# Altium schematic sheet FPGA_B34.SchDoc(FPGA_B34)
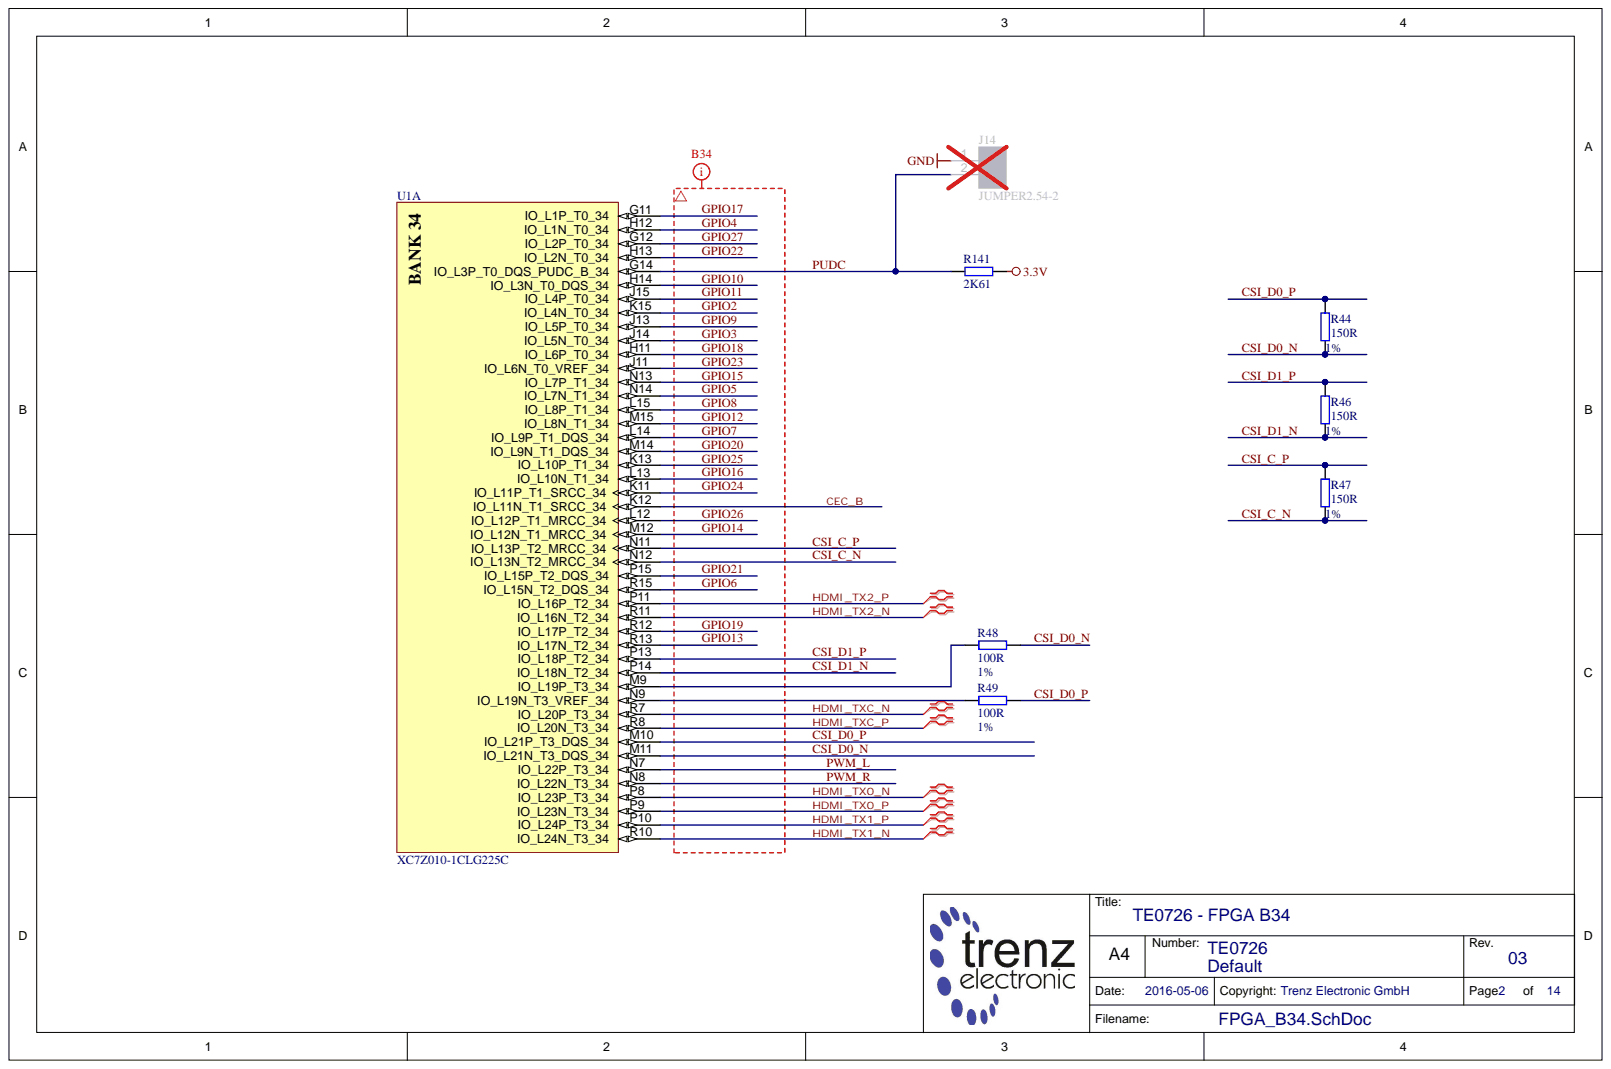
[diagram p0: FPGA_B34.SchDoc]

## Parts
J14  JUMPER2.54-2  [JUMPER2_54-2]
R44  150R  Tolerance: 1%  [RESC1005X40N]
R46  150R  Tolerance: 1%  [RESC1005X40N]
R47  150R  Tolerance: 1%  [RESC1005X40N]
R48  100R  [RESC1005X40N]
R49  100R  Tolerance: 1%  [RESC1005X40N]
R141  2K61  [RESC1005X40N]
U1  XC7Z010-1CLG225C  [BGA225C80P15X15_1300X1300X150B40N]  (part A)

## Nets
3.3V: R141.2
GND: J14.1
CEC_B: U1.K12(IO_L11N_T1_SRCC_34)
CSI_C_N: R47.2 U1.N12(IO_L13N_T2_MRCC_34)
CSI_C_P: R47.1 U1.N11(IO_L13P_T2_MRCC_34)
CSI_D0_N: R44.1 R48.2 U1.M11(IO_L21N_T3_DQS_34)
CSI_D0_P: R44.2 R49.2 U1.M10(IO_L21P_T3_DQS_34)
CSI_D1_N: R46.2 U1.P14(IO_L18N_T2_34)
CSI_D1_P: R46.1 U1.P13(IO_L18P_T2_34)
GPIO2: U1.K15(IO_L4N_T0_34)
GPIO3: U1.J14(IO_L5N_T0_34)
GPIO4: U1.H12(IO_L1N_T0_34)
GPIO5: U1.N14(IO_L7N_T1_34)
GPIO6: U1.R15(IO_L15N_T2_DQS_34)
GPIO7: U1.L14(IO_L9P_T1_DQS_34)
GPIO8: U1.L15(IO_L8P_T1_34)
GPIO9: U1.J13(IO_L5P_T0_34)
GPIO10: U1.H14(IO_L3N_T0_DQS_34)
GPIO11: U1.J15(IO_L4P_T0_34)
GPIO12: U1.M15(IO_L8N_T1_34)
GPIO13: U1.R13(IO_L17N_T2_34)
GPIO14: U1.M12(IO_L12N_T1_MRCC_34)
GPIO15: U1.N13(IO_L7P_T1_34)
GPIO16: U1.L13(IO_L10N_T1_34)
GPIO17: U1.G11(IO_L1P_T0_34)
GPIO18: U1.H11(IO_L6P_T0_34)
GPIO19: U1.R12(IO_L17P_T2_34)
GPIO20: U1.M14(IO_L9N_T1_DQS_34)
GPIO21: U1.P15(IO_L15P_T2_DQS_34)
GPIO22: U1.H13(IO_L2N_T0_34)
GPIO23: U1.J11(IO_L6N_T0_VREF_34)
GPIO24: U1.K11(IO_L11P_T1_SRCC_34)
GPIO25: U1.K13(IO_L10P_T1_34)
GPIO26: U1.L12(IO_L12P_T1_MRCC_34)
GPIO27: U1.G12(IO_L2P_T0_34)
HDMI_TX0_N: U1.P8(IO_L23P_T3_34)
HDMI_TX0_P: U1.P9(IO_L23N_T3_34)
HDMI_TX1_N: U1.R10(IO_L24N_T3_34)
HDMI_TX1_P: U1.P10(IO_L24P_T3_34)
HDMI_TX2_N: U1.R11(IO_L16N_T2_34)
HDMI_TX2_P: U1.P11(IO_L16P_T2_34)
HDMI_TXC_N: U1.R7(IO_L20P_T3_34)
HDMI_TXC_P: U1.R8(IO_L20N_T3_34)
PUDC: J14.2 R141.1 U1.G14(IO_L3P_T0_DQS_PUDC_B_34)
PWM_L: U1.N7(IO_L22P_T3_34)
PWM_R: U1.N8(IO_L22N_T3_34)
NetR48_1: R48.1 U1.M9(IO_L19P_T3_34)
NetR49_1: R49.1 U1.N9(IO_L19N_T3_VREF_34)
N00125:

## Library data of the parts
J14 = 27212: Stiftleiste gerade 1-reihig, 2pol.; Database Table Name: CON_HEADER; Mixed: THT; Trenz-Stock: Yes; AN: 27212; Component Kind: Standard; Library Name: TE.DbLib
R44 R46 R47 = 22766: WID SMD 150R 1% 0,063W; Database Table Name: R_1005_0402; Mixed: SMT; Preferred: Yes; Value: 150; AN: 22766; Case: 1005 (0402); Component Kind: Standard; Library Name: TE.DbLib; Technology: TK100
R48 R49 = 22507: WID SMD 100R 1% 0,063W; Database Table Name: R_1005_0402; Mixed: SMT; Preferred: Yes; Value: 100; AN: 22507; Case: 1005 (0402); Component Kind: Standard; Library Name: TE.DbLib; Technology: TK100
  R48: Tolerance: 1%
R141 = 23730: WID SMD 2K61 1% 0,063W; Database Table Name: R_1005_0402; Mixed: SMT; Preferred: Yes; Value: 2.61K; AN: 23730; Case: 1005 (0402); Component Kind: Standard; Library Name: TE.DbLib; Technology: TK100; Tolerance: 1%
U1 = 26308: Database Table Name: PROG_LOGIC; Mixed: SMT; Preferred: Yes; AN: 26308; Case: CLG225; Component Kind: Standard; Description: XC7Z010-1CLG225C; H-ART: XC7Z010-1CLG225C; Library Name: TE.DbLib; Trenz-Stock: Yes; HelpURL: B:\AD\adlib\datasheet\XC7\Zynq
